# Altium schematic sheet NET.SchDoc
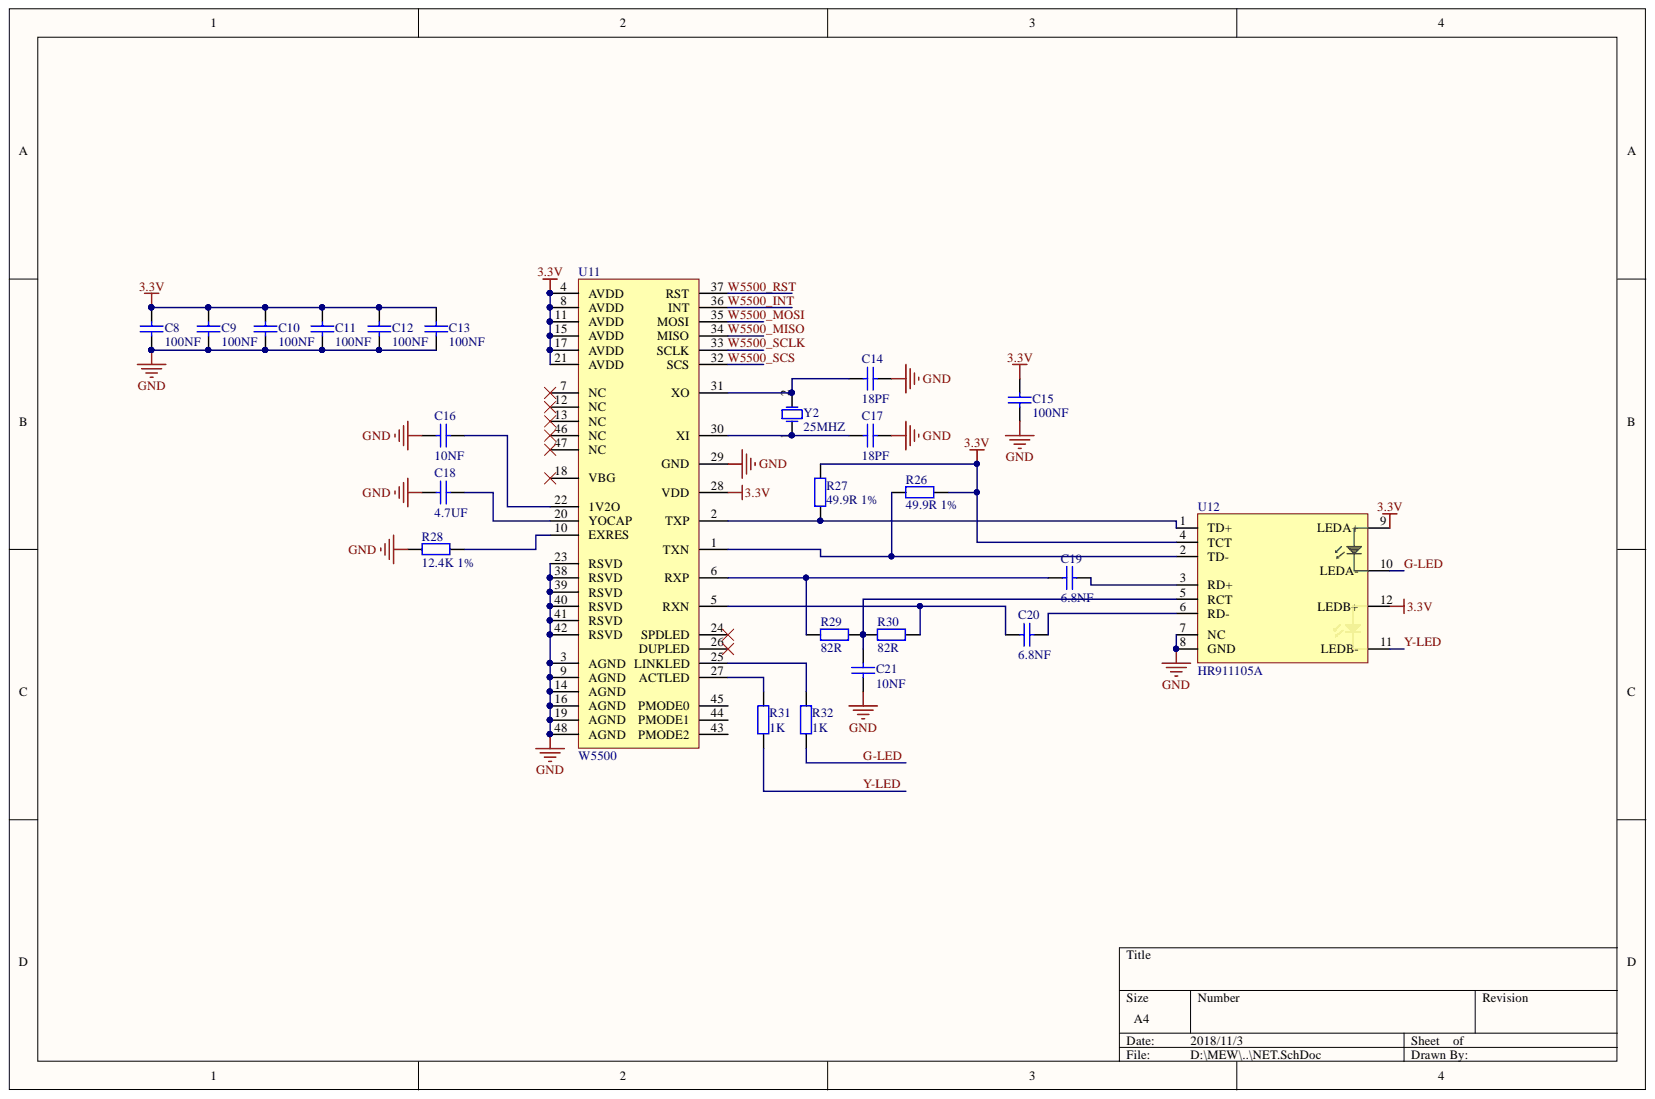
[diagram p0: NET.SchDoc]

## Parts
C8  100NF  [RC0603]
C9  100NF  [RC0603]
C10  100NF  [RC0603]
C11  100NF  [RC0603]
C12  100NF  [RC0603]
C13  100NF  [RC0603]
C14  18PF  [RC0603]
C15  100NF  [RC0603]
C16  10NF  [RC0603]
C17  18PF  [RC0603]
C18  4.7UF  [RC0603]
C19  6.8NF  [RC0603]
C20  6.8NF  [RC0603]
C21  10NF  [RC0603]
R26  49.9R 1%  [RC0603]
R27  49.9R 1%  [RC0603]
R28  12.4K 1%  [RC0603]
R29  82R  [RC0603]
R30  82R  [RC0603]
R31  1K  [RC0603]
R32  1K  [RC0603]
U11  W5500  [W5500]
U12  HR911105A  [HR911105A]
Y2  25MHZ  [XTAL-3225]

## Nets
3.3V: C8.2 C9.2 C10.2 C11.2 C12.2 C13.2 C15.1 R26.1 R27.2 U11.4(AVDD) U11.8(AVDD) U11.11(AVDD) U11.15(AVDD) U11.17(AVDD) U11.21(AVDD) U11.28(VDD) U12.4(TCT) U12.9(LEDA+) U12.12(LEDB+)
GND: C8.1 C9.1 C10.1 C11.1 C12.1 C13.1 C14.2 C15.2 C16.1 C17.2 C18.1 C21.2 R28.1 U11.3(AGND) U11.9(AGND) U11.14(AGND) U11.16(AGND) U11.19(AGND) U11.23(RSVD) U11.29(GND) U11.38(RSVD) U11.39(RSVD) U11.40(RSVD) U11.41(RSVD) U11.42(RSVD) U11.48(AGND) U12.7(NC) U12.8(GND)
G-LED: R32.1 U12.10(LEDA-)
W5500_INT: U11.36(INT)
W5500_MISO: U11.34(MISO)
W5500_MOSI: U11.35(MOSI)
W5500_RST: U11.37(RST)
W5500_SCLK: U11.33(SCLK)
W5500_SCS: U11.32(SCS)
Y-LED: R31.1 U12.11(LEDB-)
NetC14_1: C14.1 U11.31(XO) Y2.2
NetC16_2: C16.2 U11.22(1V2O)
NetC17_1: C17.1 U11.30(XI) Y2.1
NetC18_2: C18.2 U11.20(YOCAP)
NetC19_1: C19.1 R29.2 U11.6(RXP)
NetC19_2: C19.2 U12.3(RD+)
NetC20_1: C20.1 R30.2 U11.5(RXN)
NetC20_2: C20.2 U12.6(RD-)
NetC21_1: C21.1 R29.1 R30.1 U12.5(RCT)
NetR26_2: R26.2 U11.1(TXN) U12.2(TD-)
NetR27_1: R27.1 U11.2(TXP) U12.1(TD+)
NetR28_2: R28.2 U11.10(EXRES)
NetR31_2: R31.2 U11.27(ACTLED)
NetR32_2: R32.2 U11.25(LINKLED)

Not connected: U11 7(NC) 12(NC) 13(NC) 18(VBG) 24(SPDLED) 26(DUPLED) 43(PMODE2) 44(PMODE1) 45(PMODE0) 46(NC) 47(NC)

## Library data of the parts
C8-C21 = CAP-ceramic: Component Kind: Standard; Library Name: HFNADLIB.SchLib
R26-R32 = RES: Component Kind: Standard; Library Name: HFNADLIB.SchLib
Y2 = XTAL: Component Kind: Standard; Library Name: HFNADLIB.SchLib
U11 = W5500: Component Kind: Standard; Library Name: HFNADLIB.SchLib
U12 = HR911105A: Component Kind: Standard; Library Name: HFNADLIB.SchLib
